# Altium schematic sheet Schematic Prints("All Documents",Physical)
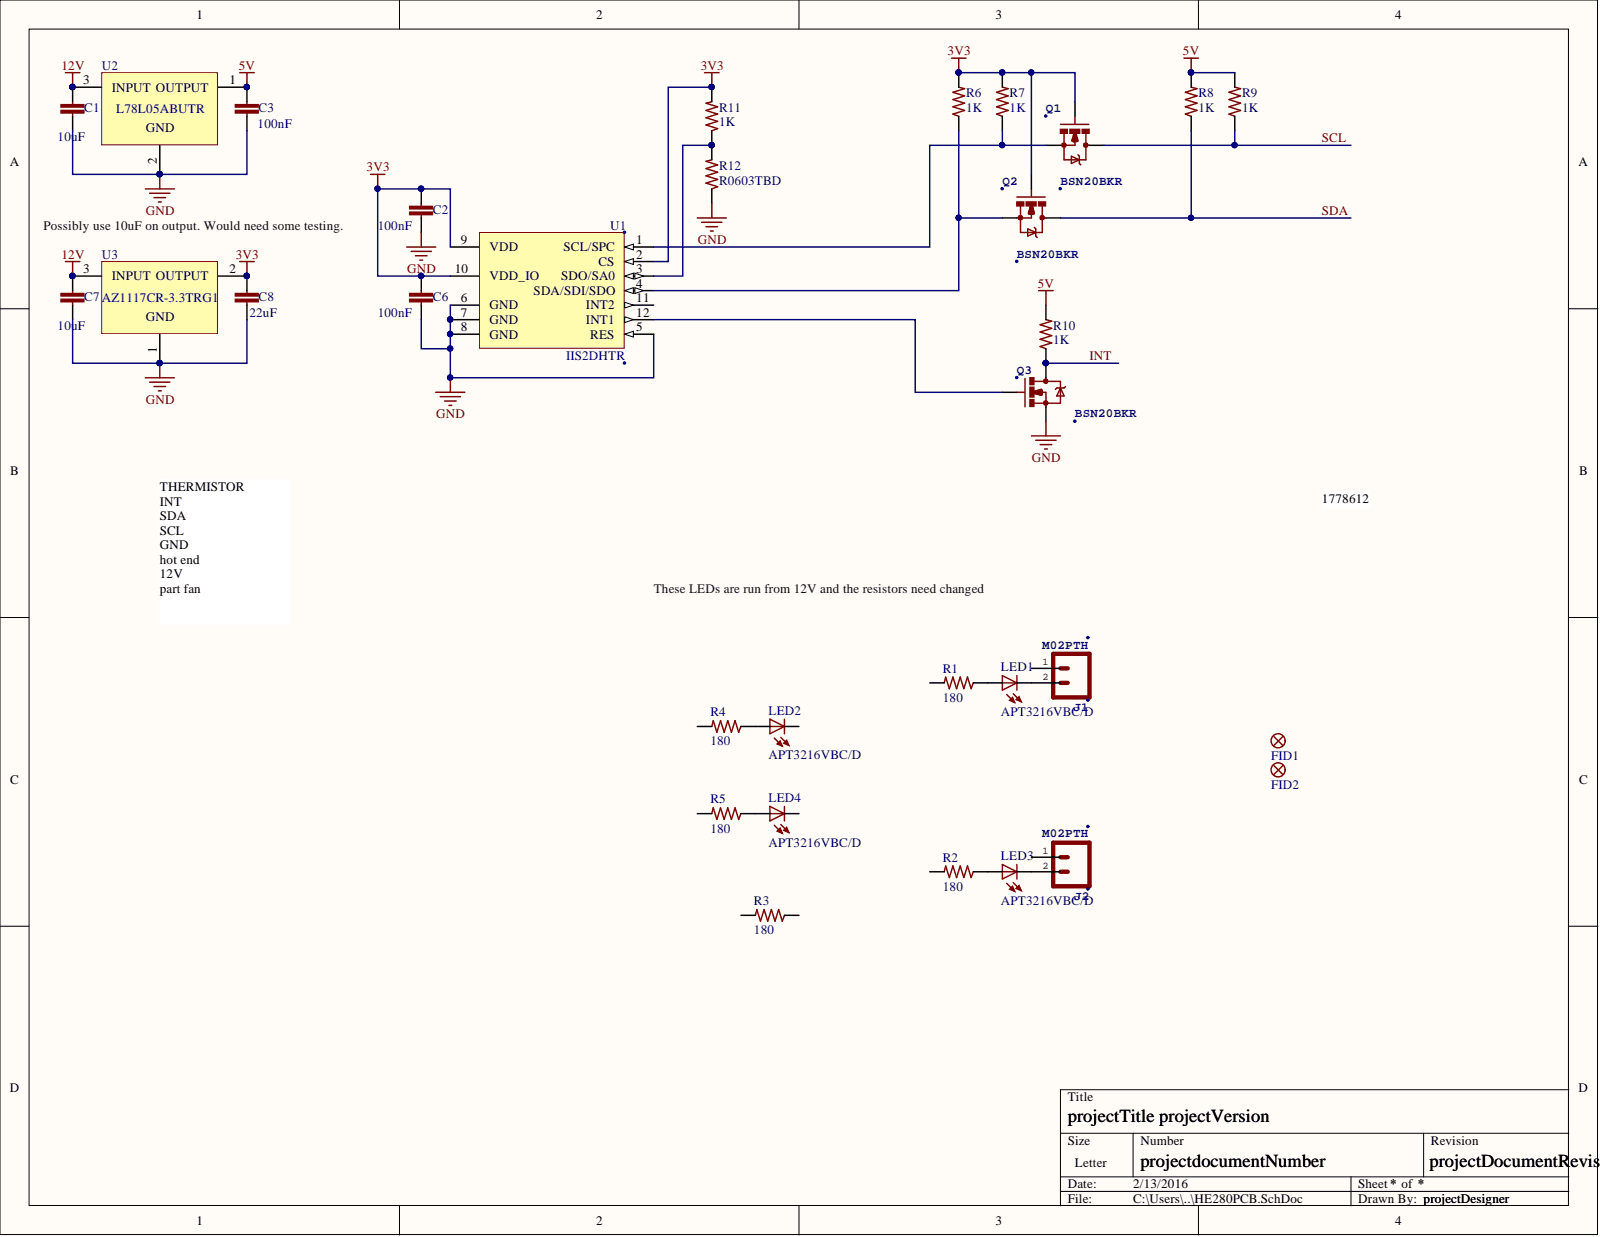
[diagram p0: Schematic Prints("All Documents",Physical)]

## Parts
C1  10uF  [C0805]
C2  100nF  [C0603]
C3  100nF  [C0603]
C6  100nF  [C0603]
C7  10uF  [C0805]
C8  22uF  [C0603]
FID1  FIDUCIAL  [FIDUCIAL-2x3-Panel]  (value not drawn)
FID2  FIDUCIAL  [FIDUCIAL-2x3-Panel]  (value not drawn)
J1  M02PTH  [1X02]
J2  M02PTH  [1X02]
LED1  APT3216VBC/D  [DIODE_1206]
LED2  APT3216VBC/D  [DIODE_1206]
LED3  APT3216VBC/D  [DIODE_1206]
LED4  APT3216VBC/D  [DIODE_1206]
Q1  BSN20BKR  [SOT23-3]
Q2  BSN20BKR  [SOT23-3]
Q3  BSN20BKR  [SOT23-3]
R1  180  [R0805]
R2  180  [R0805]
R3  180  [R0805]
R4  180  [R0805]
R5  180  [R0805]
R6  1K  [R0603]
R7  1K  [R0603]
R8  1K  [R0603]
R9  1K  [R0603]
R10  1K  [R0603]
R11  1K  [R0603]
R12  R0603TBD  [R0603]
U1  IIS2DHTR  [LGA12C50P4X4_200X200X100]
U2  L78L05ABUTR  [SOT89-150P347X160-3L]
U3  AZ1117CR-3.3TRG1  [SOT89-150P347X160-3L]

## Nets
3V3: C2.1 C6.1 C8.1 Q1.1 Q2.1 R6.2 R7.2 R11.2 U1.2(CS) U1.9(VDD) U1.10(VDD_IO) U3.2
5V: C3.1 R8.2 R9.2 R10.2 U2.1
12V: C1.1 C7.1 U2.3 U3.3
GND: C1.2 C2.2 C3.2 C6.2 C7.2 C8.2 Q3.2 R12.1 U1.5(RES) U1.6(GND) U1.7(GND) U1.8(GND) U2.2 U3.1
INT: Q3.3 R10.1
SCL: Q1.3 R9.1
SDA: Q2.3 R8.1
NetJ1_1: J1.1
NetJ1_2: J1.2 LED1.C
NetJ2_1: J2.1
NetJ2_2: J2.2 LED3.C
NetLED1_A: LED1.A R1.1
NetLED2_A: LED2.A R4.1
NetLED2_C: LED2.C
NetLED3_A: LED3.A R2.1
NetLED4_A: LED4.A R5.1
NetLED4_C: LED4.C
NetQ1_2: Q1.2 R7.1 U1.1(SCL/SPC)
NetQ2_2: Q2.2 R6.1 U1.4(SDA/SDI/SDO)
NetQ3_1: Q3.1 U1.12(INT1)
NetR1_2: R1.2
NetR2_2: R2.2
NetR3_1: R3.1
NetR3_2: R3.2
NetR4_2: R4.2
NetR5_2: R5.2
NetR11_1: R11.1 R12.2 U1.3(SDO/SA0)
NetU1_11: U1.11(INT2)

## Library data of the parts
C1 C7 = GRM21BR61C106KE15K: CAP CER 10UF 16V X5R 0805; LastRevisionNote: ImportComponents; Component Kind: Standard; LastRevisionDate: 23-Apr-2014; Library Name: 3Delight.SCHLIB; ManufacturerPartNumber: GRM21BR61C106KE15K; Published: 23-Apr-2014; Signal Integrity: Model Name; Simulation: Model Name; VALUE: 10uF; Publisher: UltiMachine
C2 C3 C6 = C0603C104K5RACTU: CAP CER 0603 100nF 50V 10% X7R; LastRevisionNote: ImportComponents; Component Kind: Standard; LastRevisionDate: 23-Apr-2014; Library Name: 3Delight.SCHLIB; ManufacturerPartNumber: C0603C104K5RACTU; Published: 23-Apr-2014; Signal Integrity: Model Name; Simulation: Model Name; VALUE: 100nF; Publisher: UltiMachine
C8 = GRM188R60J226MEA0J: CAP CER 22UF 6.3V X5R 0603; LastRevisionNote: ImportComponents; Component Kind: Standard; LastRevisionDate: 23-Apr-2014; Library Name: 3Delight.SCHLIB; ManufacturerPartNumber: GRM188R60J226MEA0J; Published: 23-Apr-2014; Signal Integrity: Model Name; Simulation: Model Name; VALUE: 22uF; Publisher: UltiMachine
FID1 FID2 = FIDUCIAL: LastRevisionNote: ImportComponents; Component Kind: Standard; Description: Fiducial; LastRevisionDate: 23-Apr-2014; Library Name: 3Delight.SCHLIB; Published: 23-Apr-2014; Publisher: UltiMachine
J1 J2 = M02PTH: Connector 2x1 2.54mm PTH; LastRevisionNote: ImportComponents; Component Kind: Standard; LastRevisionDate: 23-Apr-2014; Library Name: 3Delight.SCHLIB; Published: 23-Apr-2014; Publisher: UltiMachine
LED1-LED4 = APT3216VBC/D: LED BLUE CLEAR 1206 SMD; LastRevisionNote: ImportComponents; Component Kind: Standard; LastRevisionDate: 23-Apr-2014; Library Name: 3Delight.SCHLIB; Published: 23-Apr-2014; VALUE: APT3216VBC/D; Publisher: UltiMachine
Q1-Q3 = BSN20BKR: MOSFET N-CH 60V 0.265A SOT-23; LastRevisionNote: ImportComponents; Component Kind: Standard; LastRevisionDate: 23-Apr-2014; Library Name: 3Delight.SCHLIB; ManufacturerPartNumber: BSN20BKR; Published: 23-Apr-2014; Publisher: UltiMachine
R1-R5 = CRCW0805180RJNEA: Resistor; LastRevisionNote: ImportComponents; Component Kind: Standard; LastRevisionDate: 23-Apr-2014; Library Name: 3Delight.SCHLIB; Published: 23-Apr-2014; Signal Integrity: Model Name; Simulation: Model Name; VALUE: 180; Publisher: UltiMachine
R6-R11 = ERJ-3EKF1001V: RES 0603 1K 100mW 1%; LastRevisionNote: ImportComponents; Component Kind: Standard; LastRevisionDate: 23-Apr-2014; Library Name: 3Delight.SCHLIB; ManufacturerPartNumber: ERJ-3EKF1001V; Published: 23-Apr-2014; Signal Integrity: Model Name; Simulation: Model Name; VALUE: 1K; Publisher: UltiMachine
R12 = R0603TBD: Resistor; LastRevisionNote: ImportComponents; Component Kind: Standard; LastRevisionDate: 23-Apr-2014; Library Name: 3Delight.SCHLIB; Published: 23-Apr-2014; Signal Integrity: Model Name; Simulation: Model Name; VALUE: R0603TBD; Publisher: UltiMachine
U1 = IIS2DHTR: 3-axis accelerometer with digital output; Component Kind: Standard; Library Name: 3Delight.SCHLIB
U2 = L78L05ABUTR: IC REG LDO 5V 0.1A SOT89-3; Component Kind: Standard; Library Name: 3Delight.SCHLIB
U3 = AZ1117CR-3.3TRG1: IC REG LDO 3.3V 0.8A SOT89; Component Kind: Standard; Library Name: 3Delight.SCHLIB
